# Altium schematic sheet nrf51x22_ak.SchDoc(nrf51x22_ak)
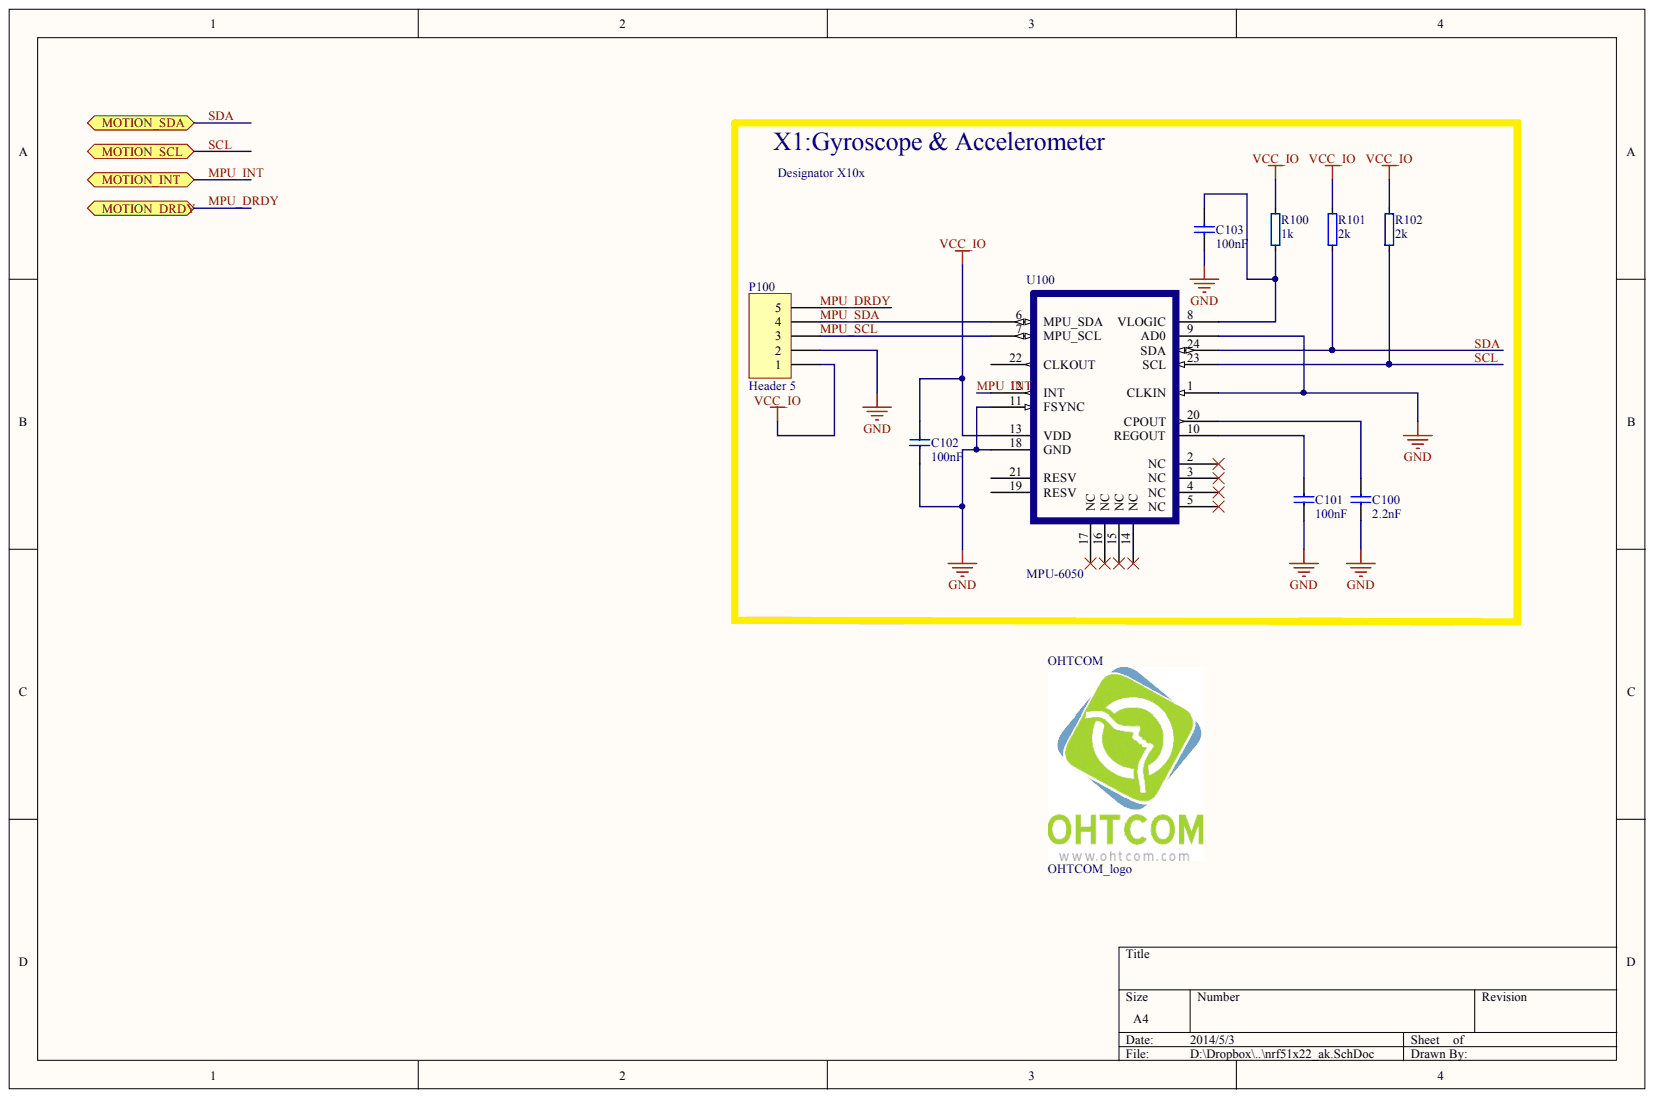
[diagram p0: nrf51x22_ak.SchDoc]

## Parts
C100  2.2nF  [0402]
C101  100nF  [0402]
C102  100nF  [0402]
C103  100nF  [0402]
OHTCOM  OHTCOM_logo
P100  Header 5
R100  1k  [0402]
R101  2k  [0402]
R102  2k  [0402]
U100  MPU-6050

## Ports
MOTION_DRDY, MOTION_INT, MOTION_SCL, MOTION_SDA

## Nets
GND: C100.2 C101.2 C102.2 C103.2 P100.2 U100.1(CLKIN) U100.9(AD0) U100.11(FSYNC) U100.18(GND) U100.25
VCC_IO: C102.1 P100.1 R100.1 R101.1 R102.1 U100.13(VDD)
MPU_DRDY: P100.5 ; port MOTION_DRDY
MPU_INT: U100.12(INT) ; port MOTION_INT
MPU_SCL: P100.3 U100.7(MPU_SCL)
MPU_SDA: P100.4 U100.6(MPU_SDA)
SCL: R102.2 U100.23(SCL) ; port MOTION_SCL
SDA: R101.2 U100.24(SDA) ; port MOTION_SDA
NetC100_1: C100.1 U100.20(CPOUT)
NetC101_1: C101.1 U100.10(REGOUT)
NetC103_1: C103.1 R100.2 U100.8(VLOGIC)

Not connected: U100 2(NC) 3(NC) 4(NC) 5(NC) 14(NC) 15(NC) 16(NC) 17(NC) 19(RESV) 21(RESV) 22(CLKOUT)

## Library data of the parts
C100 = (no symbol name): Part Number: C0402-2n2; Note: 50V; Artikkelnr Noca: 20039221; Manufacturer: *; Manufacturer Part Number: *; Datasheet: *; LatestRevisionDate: 2009-11-23; Pin Count: 2; Published: 2009-05-08; Value: 2.2nF; Supplier: *; Supplier part number: *; 2nd source Supplier: *; 2nd source part number: *; Publisher: Nordic Semiconductor
C101-C103 = (no symbol name): Part Number: C0402-100n; Note: 16V; Artikkelnr Noca: 20039200; Manufacturer: *; Manufacturer Part Number: *; Datasheet: *; LatestRevisionDate: 2009-11-23; Pin Count: 2; Published: 2009-05-08; Value: 100nF; Supplier: *; Supplier part number: *; 2nd source Supplier: *; 2nd source part number: *; Publisher: Nordic Semiconductor
R100-R102 = (no symbol name): Part Number: R0402-100k; Note: Chip Res 1%; Artikkelnr Noca: 27049121; Manufacturer: *; Manufacturer Part Number: *; Datasheet: *; LatestRevisionDate: 2009-11-23; Pin Count: 2; Published: 2009-05-08; Supplier: Farnell; Supplier part number: 173-8877; 2nd source Supplier: *; 2nd source part number: *; Publisher: Nordic Semiconductor
  R100: Value: 1k
  R101 R102: Value: 2k
OHTCOM P100 U100 = (no symbol name)
  P100: LatestRevisionDate: 17-Jul-2002; LatestRevisionNote: Re-released for DXP Platform.; Publisher: Altium Limited
  U100: Value: MPU-6050
